# Altium schematic sheet rasp_sheild_main.SchDoc
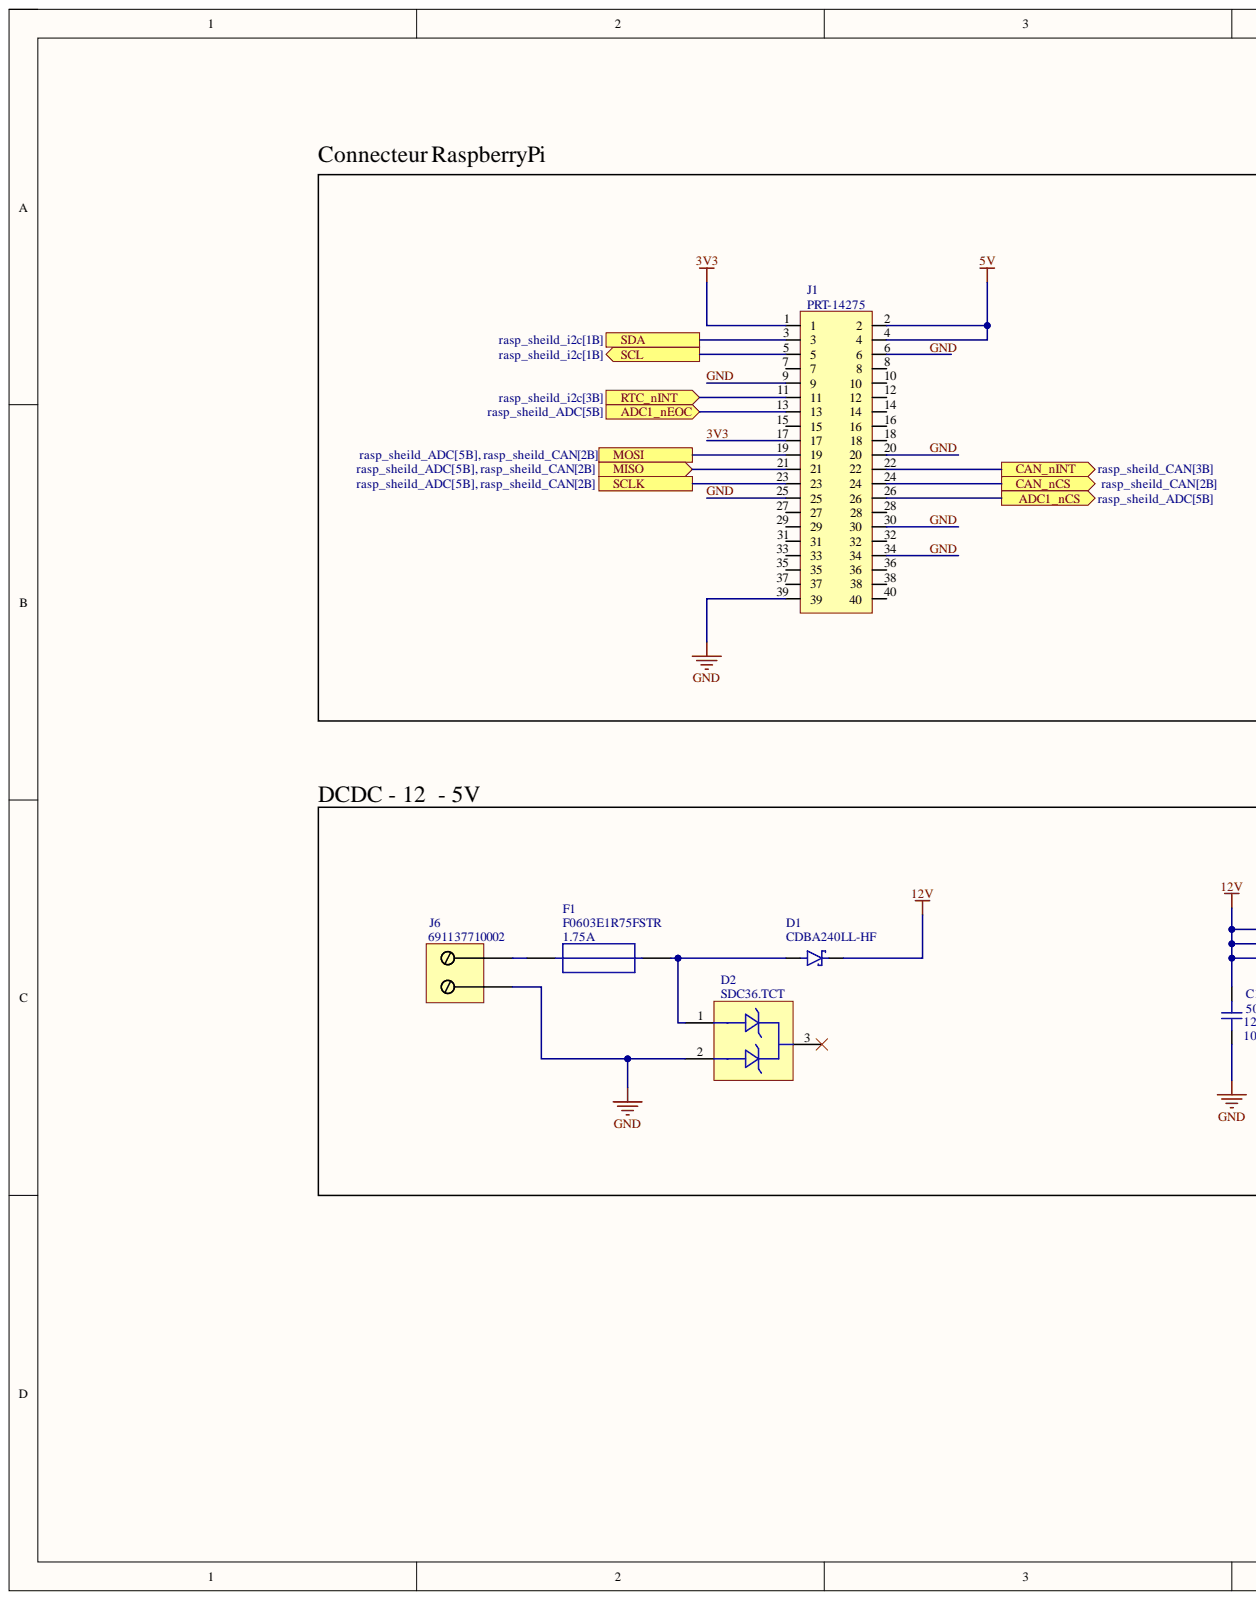
[diagram p0: rasp_sheild_main.SchDoc, left]
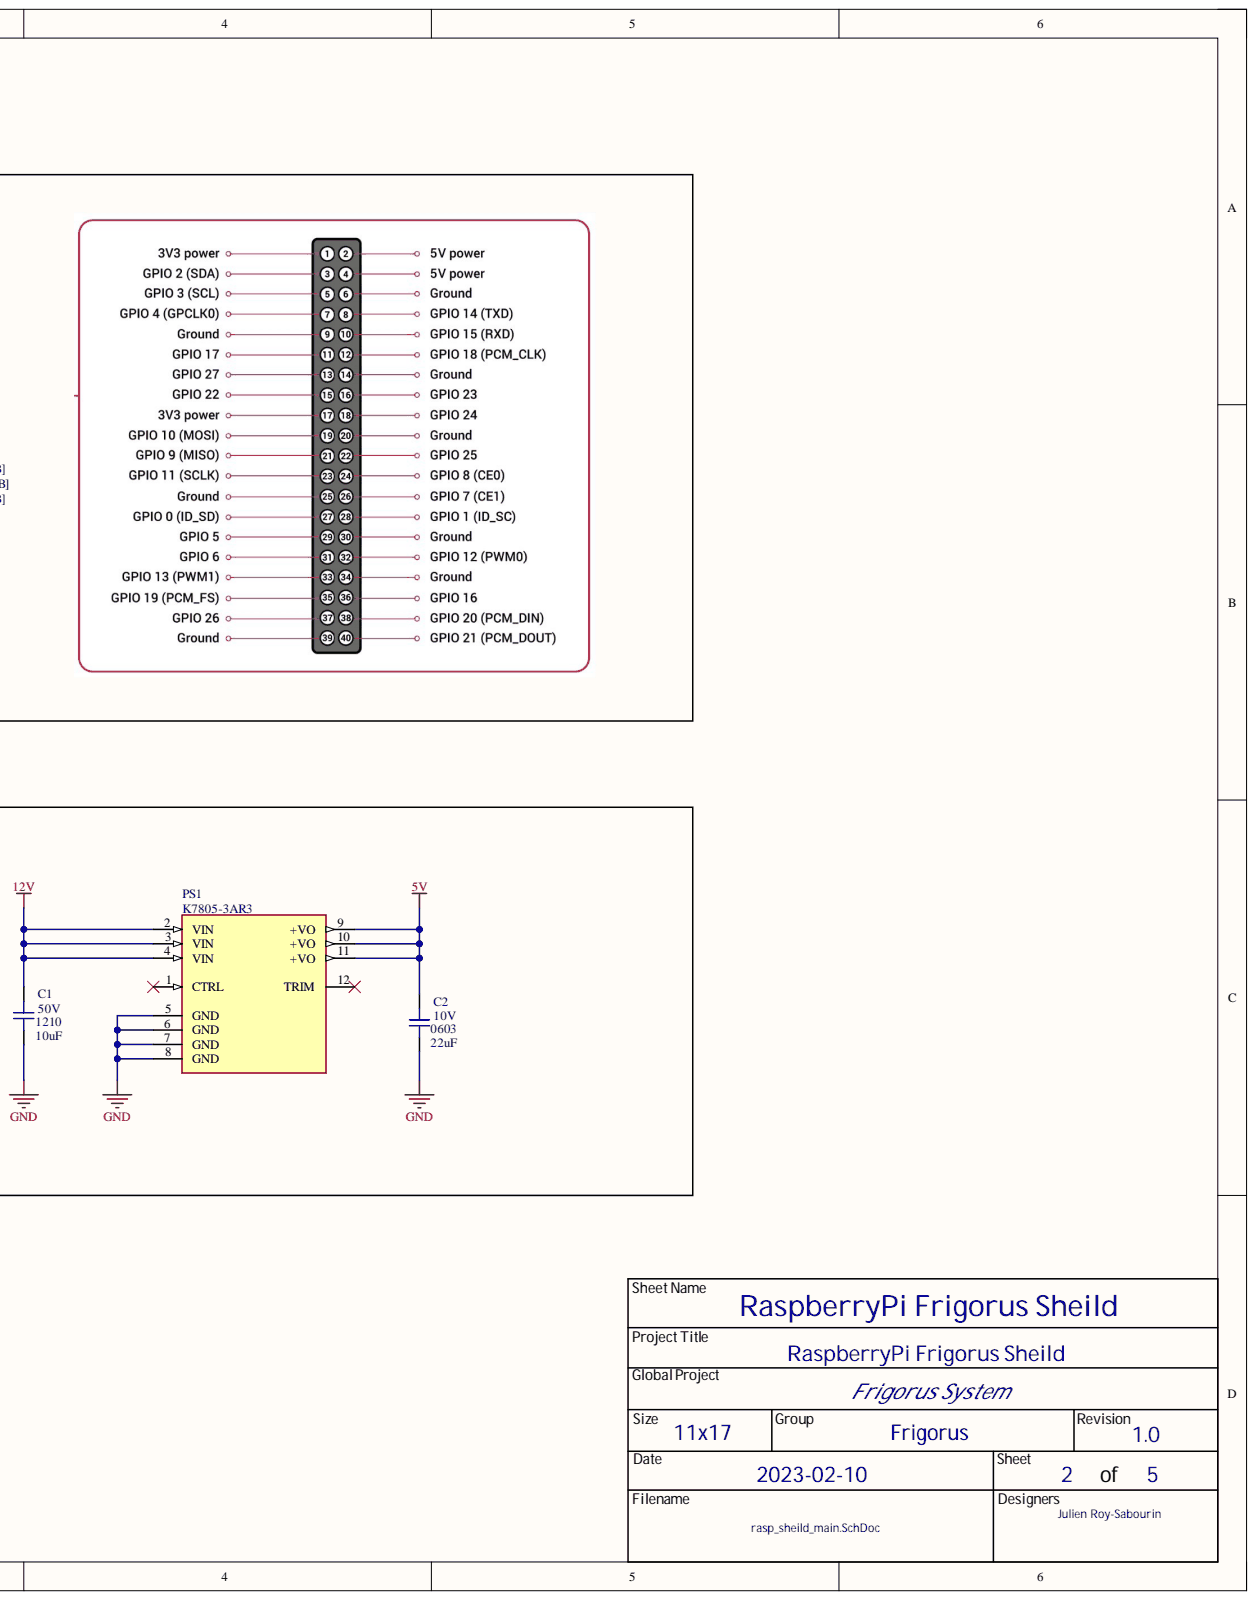
[diagram p1: rasp_sheild_main.SchDoc, right]

## Parts
C1  value 10uF  Package: 1210  Voltage Rating: 50V  [CAP_1210]  (hidden comment: CL32B106KBJNNNE)
C2  value 22uF  Package: 0603  Voltage Rating: 10V  [CAP_0603]  (hidden comment: CL10A226MP8NUNE)
D1  CDBA240LL-HF  [DO-214]
D2  SDC36.TCT  [SOT-23-3]
F1  F0603E1R75FSTR  value 1.75A  [RES_0603]
J1  PRT-14275  [PRT-14275]
J6  691137710002  [691137710002]
PS1  K7805-3AR3  [CONV_K7805-3AR3]

## Ports
ADC1_nCS, ADC1_nEOC, CAN_nCS, CAN_nINT, MISO, MOSI, RTC_nINT, SCL, SCLK, SDA

## Nets
5V: C2.2 J1.2 J1.4 PS1.9(+VO) PS1.10(+VO) PS1.11(+VO)
12V: C1.2 D1.C PS1.2(VIN) PS1.3(VIN) PS1.4(VIN)
3V3: J1.1 J1.17
ADC1_nCS: J1.26 ; port ADC1_nCS
ADC1_nEOC: J1.13 ; port ADC1_nEOC
CAN_nCS: J1.24 ; port CAN_nCS
CAN_nINT: J1.22 ; port CAN_nINT
GND: C1.1 C2.1 D2.2 J1.6 J1.9 J1.20 J1.25 J1.30 J1.34 J1.39 J6.2 PS1.5(GND) PS1.6(GND) PS1.7(GND) PS1.8(GND)
MISO: J1.21 ; port MISO
MOSI: J1.19 ; port MOSI
RTC_nINT: J1.11 ; port RTC_nINT
SCL: J1.5 ; port SCL
SCLK: J1.23 ; port SCLK
SDA: J1.3 ; port SDA
NetD1_A: D1.A D2.1 F1.2
NetF1_1: F1.1 J6.1

Not connected: D2 3; J1 7 8 10 12 14 15 16 18 27 28 29 31 32 33 35 36 37 38 40; PS1 1(CTRL) 12(TRIM)

## Library data of the parts
C1 = CAP_1210: XXX - Description - Package; GRAMS Lib Spec: 2.7; Component Kind: Standard; Library Name: royj1311.SchLib
C2 = CAP_0603: XXX - Description - Package; GRAMS Lib Spec: 2.7; Component Kind: Standard; Library Name: royj1311.SchLib
D1 = CDBA240LL-HF: Diode 40 V 2A Surface Mount DO-214AC (SMA); GRAMS Lib Spec: 2.7; Component Kind: Standard; Library Name: royj1311.SchLib
D2 = SDC36.TCT: 45V Clamp 4A (1.2/50µs) Ipp Tvs Diode Surface Mount SOT-23-3; GRAMS Lib Spec: 2.7; Component Kind: Standard; Library Name: royj1311.SchLib
F1 = F0603E1R75FSTR: 1.75 A AC 32 V DC Fuse Board Mount (Cartridge Style Excluded) Surface Mount 0603 (1608 Metric); GRAMS Lib Spec: 2.7; Component Kind: Standard; Library Name: royj1311.SchLib
J1 = PRT-14275: Raspberry Pi - Connector  2x20 2.54mm; GRAMS Lib Spec: 2.7; Component Kind: Standard; Supplier 1: Digi-Key; Library Name: royj1311.SchLib
J6 = 691137710002: 2 Position Wire to Board Terminal Block Horizontal with Board 0.197" (5.00mm) Through Hole; GRAMS Lib Spec: 2.7; Component Kind: Standard; Library Name: royj1311.SchLib
PS1 = K7805-3AR3: Non-Isolated PoL Module DC DC Converter 1 Output 5V - - - 3A 8V - 36V Input; MF: Mornsun America, LLC; Availability: In Stock; Check_prices: https://www.snapeda.com/parts/K7805-3AR3/MORNSUN/view-part/?ref=eda; Component Kind: Standard; MP: K7805-3AR3; Package: SIP-12-12 MORNSUN; Price: None; Purchase-URL: https://pricing.snapeda.com/search/part/K7805-3AR3/?ref=eda; SnapEDA_Link: https://www.snapeda.com/parts/K7805-3AR3/MORNSUN/view-part/?ref=snap; Supplier 1: Digi-Key; Supplier Part Number 1: 2725-K7805-3AR3-ND; Library Name: royj1311.SchLib
